# Altium schematic sheet J1708 LIN H-Bridge CAN1 I2C Pots Rev 6.SchDoc("J1708 LIN H-Bridge CAN1 I2C Pots Rev 6")
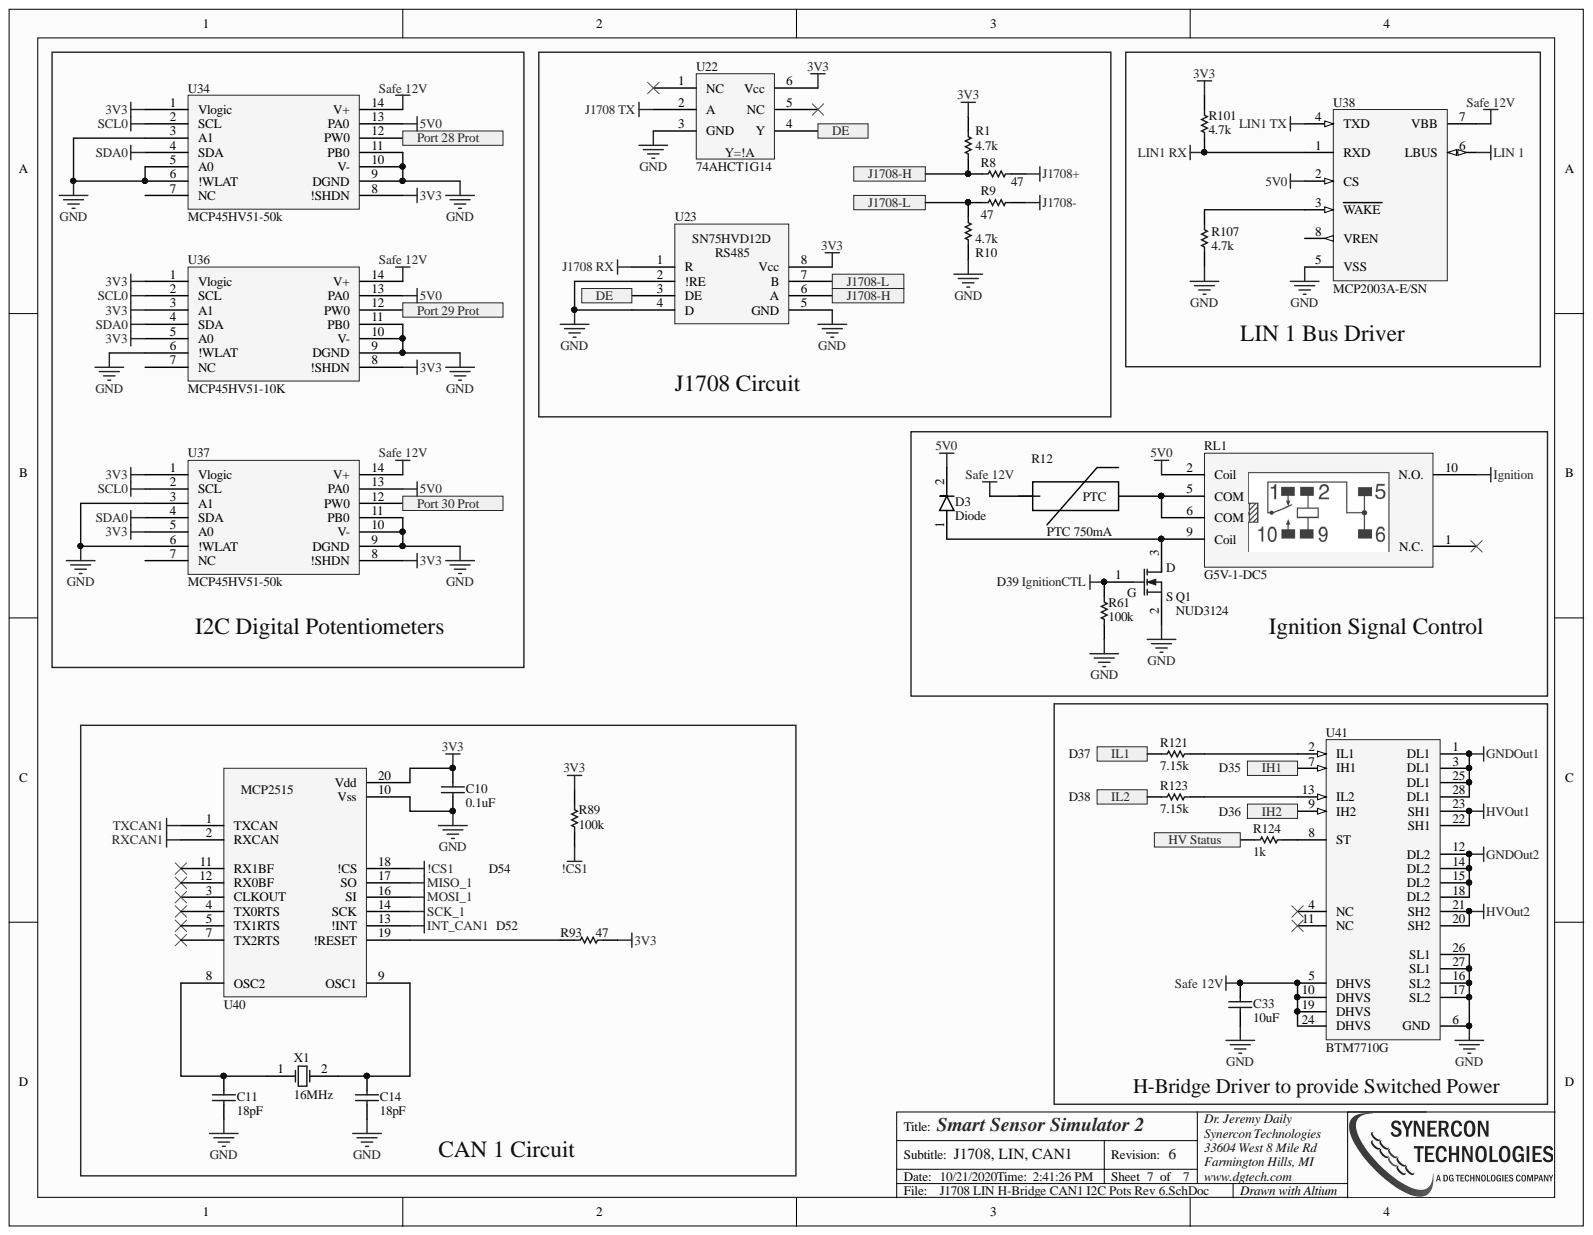
[diagram p0: J1708 LIN H-Bridge CAN1 I2C Pots Rev 6.SchDoc]

## Parts
C10  0.1uF  [0603]
C11  18pF  [0603]
C14  18pF  [0603]
C33  10uF  [0603]
D3  Diode  [CST2C]
Q1  NUD3124  [ONSC-SOT-23-3-318-08_V]
R1  4.7k  [0603]
R8  47  [0603]
R9  47  [0603]
R10  4.7k  [0603]
R12  PTC 750mA  [1206]
R61  100k  [0603]
R89  100k  [0603]
R93  47  [0603]
R101  4.7k  [0603]
R107  4.7k  [0603]
R121  7.15k  [0603]
R123  7.15k  [0603]
R124  1k  [0603]
RL1  G5V-1-DC5  [OMRON G5V Relay]
U22  74AHCT1G14  [SOT-353]
U23  SN75HVD12D (3.3v RS485)  [SOIC-8]  (value not drawn)
U34  MCP45HV51-50k  [TSSOP-ST14_M]
U36  MCP45HV51-10K  [TSSOP-ST14_M]
U37  MCP45HV51-50k  [TSSOP-ST14_M]
U38  MCP2003A-E/SN  [SOIC-SN8_N]
U40  MCP2515  [TI-TSSOP20]
U41  BTM7710G  [INF-PG-DSO-28-22_M]
X1  16MHz  [XTAL 2 pad]

## Ports
DE, HV Status, IH1, IH2, IL1, IL2, J1708-H, J1708-L, Port 28 Prot, Port 29 Prot, Port 30 Prot

## Nets
3V3: C10.2 R1.1 R89.2 R93.2 R101.2 U22.6(Vcc) U23.8(Vcc) U34.1(Vlogic) U34.8(!SHDN) U36.1(Vlogic) U36.3(A1) U36.5(A0) U36.8(!SHDN) U37.1(Vlogic) U37.5(A0) U37.8(!SHDN) U40.20(Vdd)
5V0: D3.2 RL1.2(Coil) U34.13(PA0) U36.13(PA0) U37.13(PA0) U38.2(CS)
!CS1: R89.1 U40.18(!CS)
GND: C10.1 C11.1 C14.1 C33.2 Q1.2 R10.2 R61.1 R107.2 U22.3(GND) U23.2(!RE) U23.4(D) U23.5(GND) U34.3(A1) U34.5(A0) U34.6(!WLAT) U34.9(DGND) U34.10(V-) U34.11(PB0) U36.6(!WLAT) U36.9(DGND) U36.10(V-) U36.11(PB0) U37.3(A1) U37.6(!WLAT) U37.9(DGND) U37.10(V-) U37.11(PB0) U38.5(VSS) U40.10(Vss) U41.6(GND) U41.16(SL2) U41.17(SL2) U41.26(SL1) U41.27(SL1)
GNDOut1: U41.1(DL1) U41.3(DL1) U41.25(DL1) U41.28(DL1)
GNDOut2: U41.12(DL2) U41.14(DL2) U41.15(DL2) U41.18(DL2)
HVOut1: U41.22(SH1) U41.23(SH1)
HVOut2: U41.20(SH2) U41.21(SH2)
Ignition: RL1.10(N.O.)
IgnitionCTL: Q1.1 R61.2
INT_CAN1: U40.13(!INT)
J1708 RX: U23.1(R)
J1708 TX: U22.2(A)
J1708+: R8.2
J1708-: R9.2
LIN1 RX: R101.1 U38.1(RXD)
LIN1 TX: U38.4(TXD)
LIN 1: U38.6(LBUS)
MISO_1: U40.17(SO)
MOSI_1: U40.16(SI)
RXCAN1: U40.2(RXCAN)
Safe 12V: C33.1 R12.1 U34.14(V+) U36.14(V+) U37.14(V+) U38.7(VBB) U41.5(DHVS) U41.10(DHVS) U41.19(DHVS) U41.24(DHVS)
SCK_1: U40.14(SCK)
SCL0: U34.2(SCL) U36.2(SCL) U37.2(SCL)
SDA0: U34.4(SDA) U36.4(SDA) U37.4(SDA)
TXCAN1: U40.1(TXCAN)
DE: U22.4(Y) U23.3(DE) ; port DE
HV Status: R124.1 ; port HV Status
IH1: U41.7(IH1) ; port IH1
IH2: U41.9(IH2) ; port IH2
IL1: R121.1 ; port IL1
IL2: R123.1 ; port IL2
J1708-H: R1.2 R8.1 U23.6(A) ; port J1708-H
J1708-L: R9.1 R10.1 U23.7(B) ; port J1708-L
Port 28 Prot: U34.12(PW0) ; port Port 28 Prot
Port 29 Prot: U36.12(PW0) ; port Port 29 Prot
Port 30 Prot: U37.12(PW0) ; port Port 30 Prot
NetC11_2: C11.2 U40.8(OSC2) X1.1
NetC14_2: C14.2 U40.9(OSC1) X1.2
NetD3_1: D3.1 Q1.3 RL1.9(Coil)
NetR12_2: R12.2 RL1.5(COM) RL1.6(COM)
NetR93_1: R93.1 U40.19(!RESET)
NetR107_1: R107.1 U38.3(WAKE)
NetR121_2: R121.2 U41.2(IL1)
NetR123_2: R123.2 U41.13(IL2)
NetR124_2: R124.2 U41.8(ST)
N00410:

Not connected: RL1 1(N.C.); U22 1(NC) 5(NC); U34 7(NC); U36 7(NC); U37 7(NC); U38 8(VREN); U40 3(CLKOUT) 4(TX0RTS) 5(TX1RTS) 7(TX2RTS) 11(RX1BF) 12(RX0BF); U41 4(NC) 11(NC)

## Library data of the parts
C10 = Cap: CAP CER 0.1UF 50V 10% X7R 0603; PartNumber: GRM188R72A104KA35D; Manufacturer: Murata Electronics; Alternative: Acceptable; Supplier Part Number 1: 490-3285-1-ND; Supplier 1: Digi-Key; Simulation: CAP; Signal Integrity: Cap; Library Name: SSS Schematic Library.SchLib; Component Kind: Standard
C11 C14 = Cap: CAP CER 18PF 50V 5% NP0 0603; PartNumber: C0603C180J5GACTU; Manufacturer: Kemet; Supplier Part Number 1: 399-1052-1-ND; Supplier 1: Digi-Key; Simulation: CAP; Signal Integrity: Cap; Library Name: SSS Schematic Library.SchLib; Component Kind: Standard
C33 = Cap: 10µF ±20% 25V Ceramic Capacitor X5R 0603 (1608 Metric); PartNumber: GRT188R61E106ME13D; Manufacturer: Murata Electronics North America; Supplier Part Number 1: 490-12323-1-ND; Supplier 1: Digi-Key; Simulation: CAP; Signal Integrity: Cap; Library Name: SSS Integrated Library.IntLib; Component Kind: Standard
D3 = Diode: Diode Schottky 40V 2A Surface Mount DO-214AC (SMA); PartNumber: CCS15S30L3FCT-ND; Manufacturer: Toshiba Semiconductor and Storage; Supplier Part Number 1: CCS15S30L3FCT-ND; Supplier 1: Digi-Key; Simulation: DIODE; Signal Integrity: Diode; Library Name: Miscellaneous Devices.IntLib; Component Kind: Standard
Q1 = IRLML6344TRPbF: IC INDCT LOAD DRVR AUTO SOT23; PartNumber: NUD3124LT1G; Manufacturer: ON Semiconductor; Supplier Part Number 1: NUD3124LT1GOSCT-ND; Supplier 1: Digi-Key; Library Name: SSS Schematic Library.SchLib; Component Kind: Standard
R1 R10 R101 R107 = Chip Resistor: RES SMD 4.7K OHM 1% 1/10W 0603; PartNumber: ERJ-3EKF4701V; Manufacturer: Panasonic Electronic Components; Supplier Part Number 1: P4.70KHCT-ND; Supplier 1: Digi-Key; Library Name: SSS Integrated Library.IntLib; Component Kind: Standard
R8 R9 R93 = Chip Resistor: 47 Ohms ±5% 0.25W, 1/4W Chip Resistor 0603 (1608 Metric) Automotive AEC-Q200, Pulse Withstanding Thick Film; PartNumber: ERJ-PA3J470V; Manufacturer: Panasonic Electronic Components; Supplier Part Number 1: P47.00BZCT-ND; Supplier 1: Digi-Key; Library Name: SSS Integrated Library.IntLib; Component Kind: Standard
R12 = PTC: PTC RESTTBLE 0.75A 16V CHIP 1206; PartNumber: 0ZCJ0075AF2E; Manufacturer: Bel Fuse Inc; Supplier Part Number 1: 507-1805-1-ND; Supplier 1: Digi-Key; Library Name: SSS Schematic Library.SchLib; Component Kind: Standard
R61 R89 = Chip Resistor: 100 kOhms ±1% 0.1W, 1/10W Chip Resistor 0603 (1608 Metric) Automotive AEC-Q200 Thick Film; PartNumber: ERJ-3EKF1003V; Manufacturer: Panasonic Electronic Components; Alternative: Acceptable; Supplier Part Number 1: P100KHCT-ND; Supplier 1: Digi-Key; Library Name: SSS Integrated Library.IntLib; Component Kind: Standard
R121 R123 = Chip Resistor: RES SMD 7.15K OHM 1% 1/10W 0603; PartNumber: ERJ-3EKF7151V; Manufacturer: Panasonic Electronic Components; Supplier Part Number 1: P7.15KHCT-ND; Supplier 1: Digi-Key; Library Name: SSS Integrated Library.IntLib; Component Kind: Standard
R124 = Chip Resistor: RES SMD 1K OHM 1% 1/10W 0603; PartNumber: ERJ-3EKF1001V; Manufacturer: Panasonic Electronic Components; Alternative: Acceptable; Supplier Part Number 1: P1.00KHCT-ND; Supplier 1: Digi-Key; Library Name: SSS Schematic Library.SchLib; Component Kind: Standard
RL1 = Relay-G5V: OMRON RELAY GENERAL PURPOSE SPDT 1A 5V; Manufacturer: Omron Electronics Inc-EMC Div; Supplier Part Number 1: Z773-ND; Supplier 1: Digi-Key; PartNumber: G5V-1-DC5; Library Name: SSS Schematic Library.SchLib; Component Kind: Standard
U22 = 74AHCT1G14 Inverter: IC SCHMIT-TRIGG INV GATE SOT353; PartNumber: 74AHCT1G14SE-7; Manufacturer: Diodes Incorporated; Supplier Part Number 1: 74AHCT1G14SE-7DICT-ND; Supplier 1: Digi-Key; Library Name: SSS Schematic Library.SchLib; Component Kind: Standard
U23 = SN75HVD12D (3.3v RS485): IC RS485 TXRX 3/5V 8-SOIC; PartNumber: SN75HVD08DR; Manufacturer: Texas Instruments; Supplier Part Number 1: 296-37893-1-ND; Supplier 1: Digi-Key; Library Name: SSS Schematic Library.SchLib; Component Kind: Standard
U34 U37 = MCP45HVX1 Digital Pot: Digital Potentiometer 100k Ohm 1 Circuit 256 Taps I²C Interface 14-TSSOP; PartNumber: MCP45HV51-104E/ST; Manufacturer: Microchip Technology; Supplier Part Number 1: MCP45HV51-104E/ST-ND; Supplier 1: Digi-Key; Library Name: SSS Integrated Library.IntLib; Component Kind: Standard
U36 = MCP45HVX1 Digital Pot: Digital Potentiometer 10k Ohm 1 Circuit 256 Taps I²C Interface 14-TSSOP; PartNumber: MCP45HV51-103E/ST; Manufacturer: Microchip Technology; Supplier Part Number 1: MCP45HV51-103E/ST-ND; Supplier 1: Digi-Key; Library Name: SSS Integrated Library.IntLib; Component Kind: Standard
U38 = MCP2003AT-E/SN: LIN J2602 Transceiver, 8-Pin SOIC, Extended Temperature, Tape and Reel; Manufacturer: Microchip; Supplier Part Number 1: MCP2003A-E/SN-ND; Supplier 1: Digi-Key; PartNumber: MCP2003A-E/SN; Library Name: Microchip Interface.IntLib; Component Kind: Standard
U40 = MCP2515 (TSSOP): IC CAN CONTROLLER W/SPI 20TSSOP; PartNumber: MCP2515T-I/ST; Manufacturer: Microchip; Supplier Part Number 1: MCP2515T-I/STCT-ND; Supplier 1: Digi-Key; Component Kind: Standard; Library Name: Communications.SchLib
U41 = BTM7710G: TrilithIC, 4.5 to 42 V, -40 to 150 degC, PG-DSO-28-22, Reel, Green; PartNumber: BTM7710GXUMA1; Manufacturer: Infineon; Supplier Part Number 1: BTM7710GXUMA1CT-ND; Supplier 1: Digi-Key; Library Name: Infineon_Power_Motor_Driver.IntLib; Component Kind: Standard
X1 = Crystal Oscillator: CRYSTAL 16MHZ 18PF SMD; PartNumber: 7A-16.000MAAJ-T; Manufacturer: TXC CORPORATION; Supplier Part Number 1: 887-1086-1-ND; Supplier 1: Digi-Key; Library Name: SSS Schematic Library.SchLib; Component Kind: Standard
